# Altium schematic sheet HubBoard.SchDoc(HubBoard)
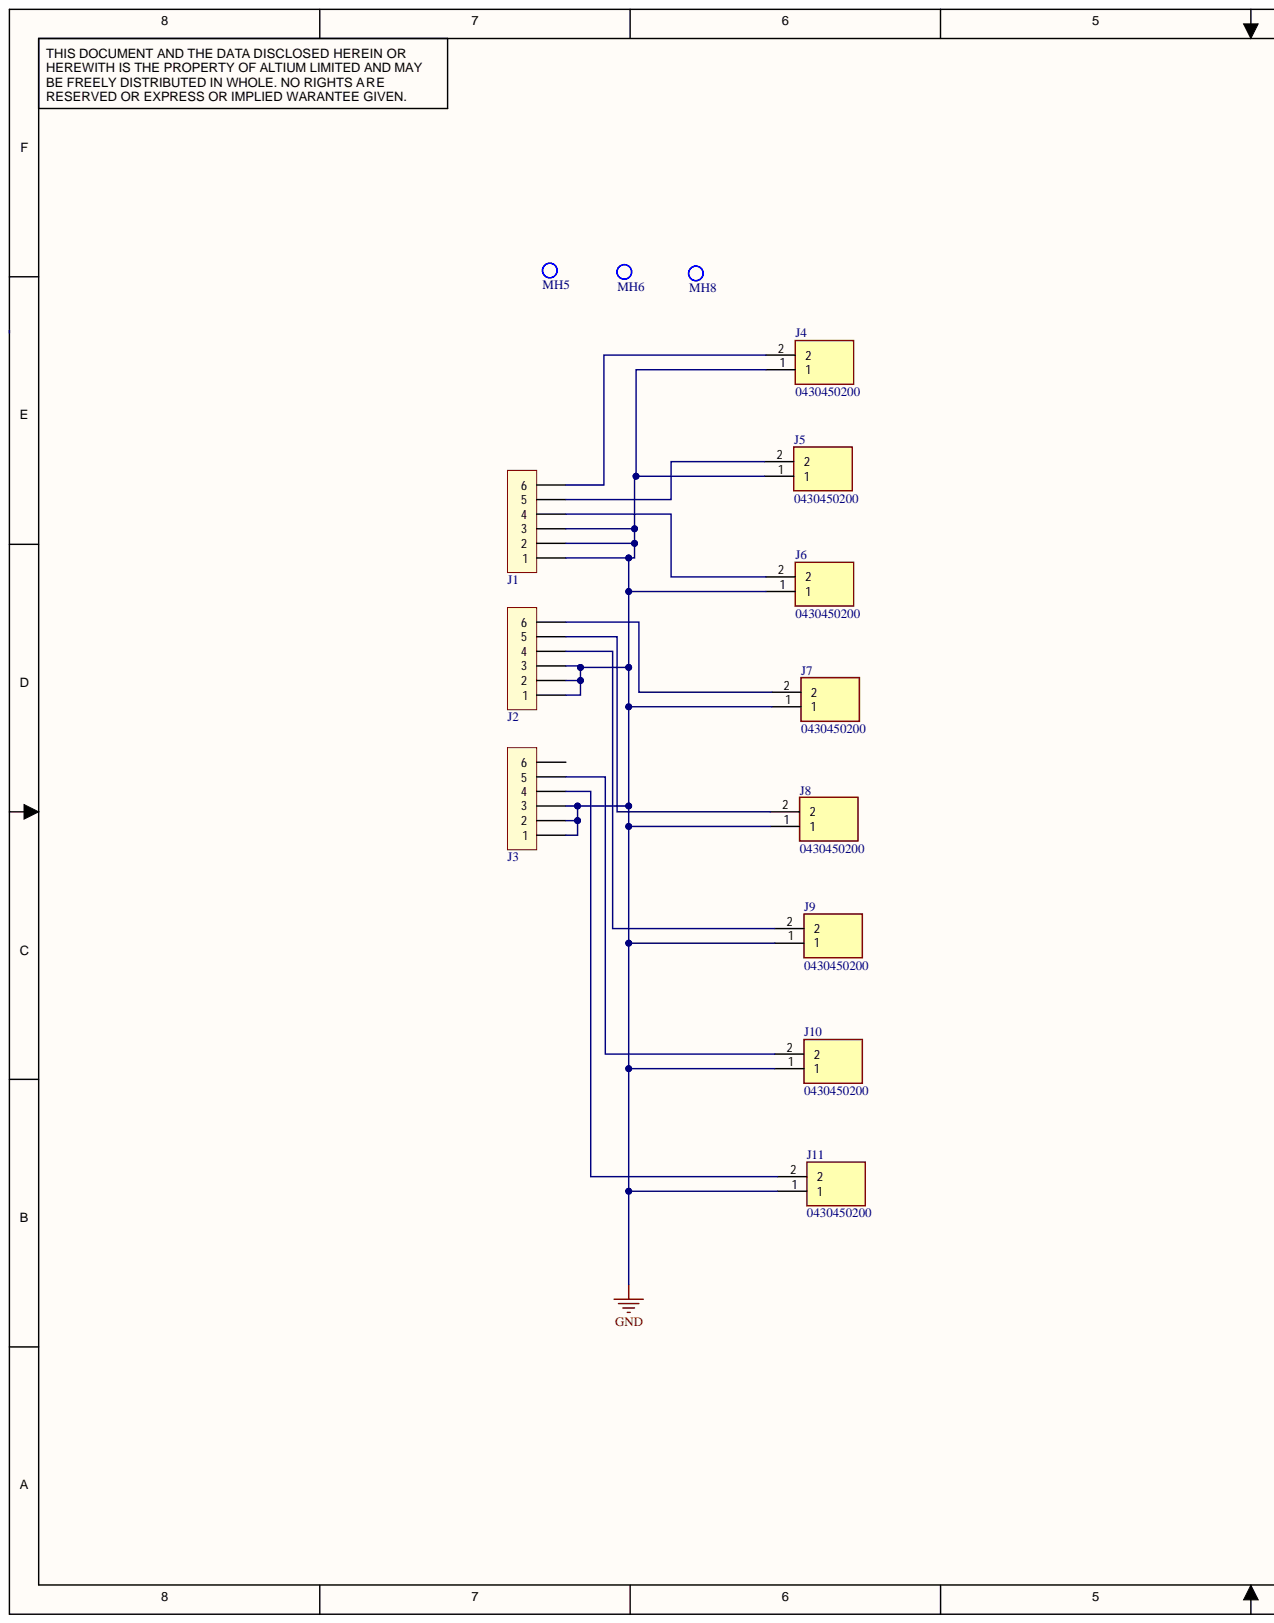
[diagram p0: HubBoard.SchDoc, left]
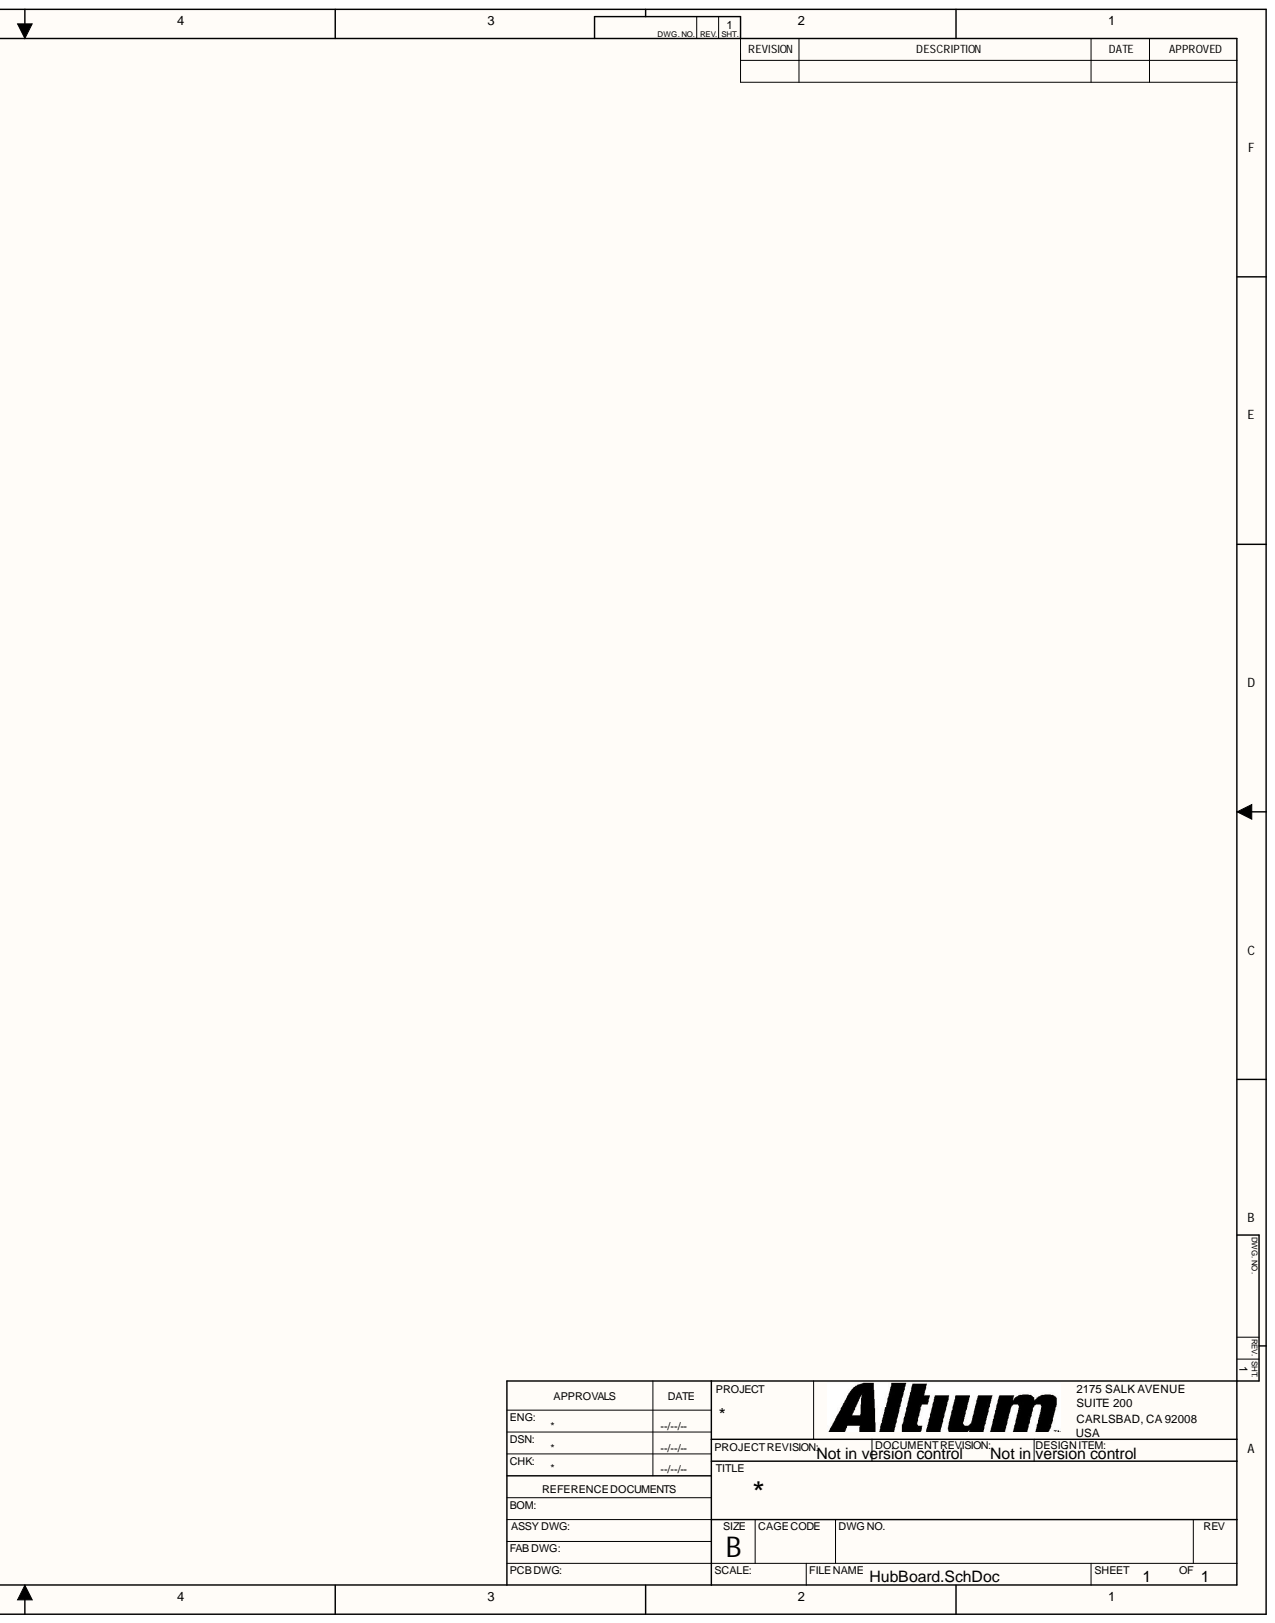
[diagram p1: HubBoard.SchDoc, right]

## Parts
J1  0430450600  [0430450600]  (value not drawn)
J2  0430450600  [0430450600]  (value not drawn)
J3  0430450600  [0430450600]  (value not drawn)
J4  0430450200  [43045-0200]
J5  0430450200  [43045-0200]
J6  0430450200  [43045-0200]
J7  0430450200  [43045-0200]
J8  0430450200  [43045-0200]
J9  0430450200  [43045-0200]
J10  0430450200  [43045-0200]
J11  0430450200  [43045-0200]
MH5  Mounting Hole  [MOUNT-HOLE]  (value not drawn)  (no BOM)
MH6  Mounting Hole  [MOUNT-HOLE]  (value not drawn)  (no BOM)
MH8  Mounting Hole  [MOUNT-HOLE]  (value not drawn)  (no BOM)

## Nets
GND: J1.1 J1.2 J1.3 J2.1 J2.2 J2.3 J3.1 J3.2 J3.3 J4.1 J5.1 J6.1 J7.1 J8.1 J9.1 J10.1 J11.1
NetJ1_4: J1.4 J6.2
NetJ1_5: J1.5 J5.2
NetJ1_6: J1.6 J4.2
NetJ2_4: J2.4 J9.2
NetJ2_5: J2.5 J8.2
NetJ2_6: J2.6 J7.2
NetJ3_4: J3.4 J11.2
NetJ3_5: J3.5 J10.2

Not connected: J3 6

## Library data of the parts
J1-J3 = 0430450600: CONN HEADER R/A 6POS 3MM; Manufacturer: Molex; Contact Length - Post: 0.125" (3.18mm); Current Rating (Amps): 8A; Material Flammability Rating: UL94 V-0; Number of Positions: 6; Row Spacing - Mating: 0.118" (3.00mm); Shrouding: Shrouded - 4 Wall; Voltage Rating: 600V; Component Kind: Standard; ComponentLink1Description: Drawing; ComponentLink1URL: https://www.molex.com/pdm_docs/sd/430450600_sd.pdf; ComponentLink2Description: Product Specification; ComponentLink2URL: https://www.molex.com/pdm_docs/ps/PS-43045.pdf; Contact Finish - Mating: Tin; Contact Finish - Post: Tin; Contact Finish Thickness - Mating: 100.0µin (2.54µm); Contact Material: Brass; Contact Shape: Square; Contact Type: Male Pin; Fastening Type: Locking Ramp; Features: Board Lock; Insulation Color: Black; Insulation Height: 0.290" (7.37mm); Insulation Material: Liquid Crystal Polymer (LCP), Glass Filled; Manufacturer Part Number: 0430450600; Mounting Type: Through Hole, Right Angle; Number of Rows: 2; Supplier 1: Digi-Key; Supplier Part Number 1: WM1815-ND; Termination: Solder; Library Name: SOARSchematics.SchLib
J4-J11 = 0430450200: Connector; Manufacturer_Name: Molex; Arrow Part Number: 0430450200; Arrow Price/Stock: https://www.arrow.com/en/products/0430450200/molex; Component Kind: Standard; Datasheet Link: http://www.molex.com/webdocs/datasheets/pdf/en-us//0430450200_PCB_HEADERS.pdf; Manufacturer_Part_Number: 0430450200; Mouser Part Number: N/A; Mouser Price/Stock: https://www.mouser.co.uk/ProductDetail/Molex/0430450200?qs=PBcRNPEHKsq8d1JP3B5UOw%3D%3D
MH5 MH6 MH8 = Mounting Hole: #4 Screw, 0.116in Diameter; Library Name: SOARSchematics.SchLib
